# Altium schematic sheet 9.BOOT.SchDoc(9.BOOT)
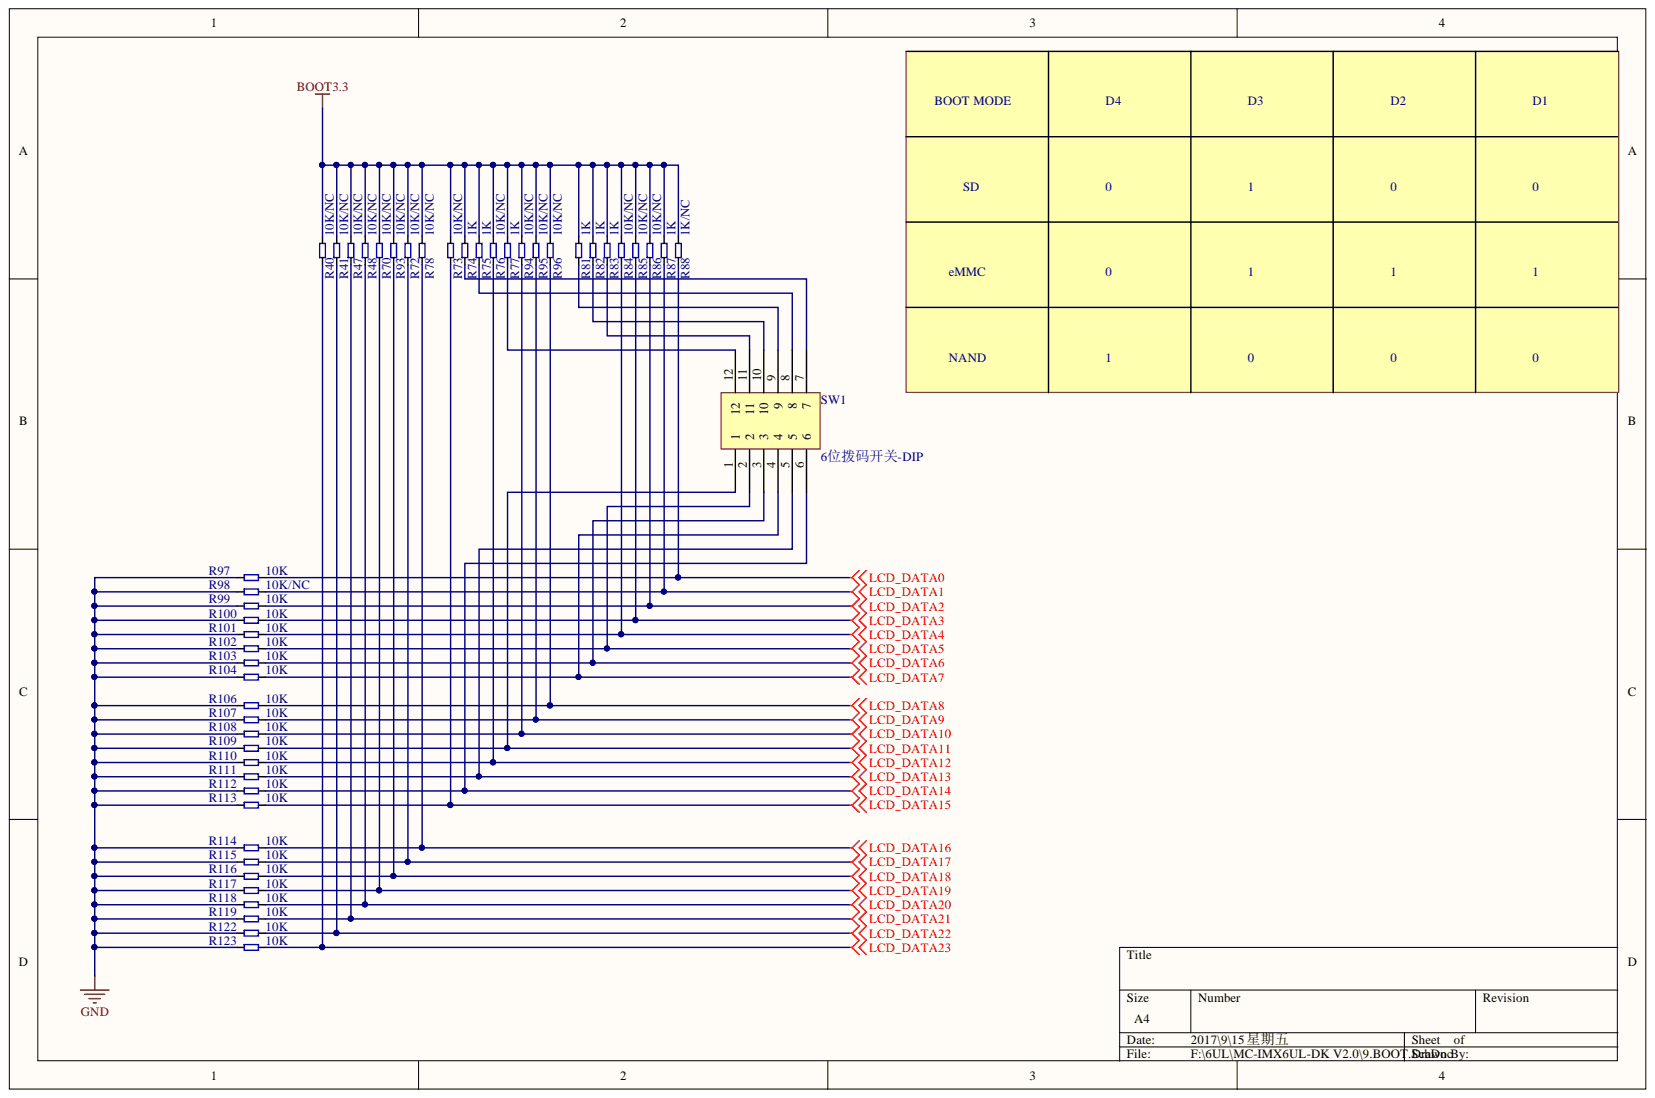
[diagram p0: 9.BOOT.SchDoc]

## Parts
R40  10K/NC  [0603R]
R41  10K/NC  [0603R]
R47  10K/NC  [0603R]
R48  10K/NC  [0603R]
R70  10K/NC  [0603R]
R72  10K/NC  [0603R]
R73  10K/NC  [0603R]
R74  1K  [0603R]
R75  1K  [0603R]
R76  10K/NC  [0603R]
R77  1K  [0603R]
R78  10K/NC  [0603R]
R81  1K  [0603R]
R82  1K  [0603R]
R83  1K  [0603R]
R84  10K/NC  [0603R]
R85  10K/NC  [0603R]
R86  10K/NC  [0603R]
R87  1K  [0603R]
R88  1K/NC  [0603R]
R93  10K/NC  [0603R]
R94  10K/NC  [0603R]
R95  10K/NC  [0603R]
R96  10K/NC  [0603R]
R97  10K  [0603R]
R98  10K/NC  [0603R]
R99  10K  [0603R]
R100  10K  [0603R]
R101  10K  [0603R]
R102  10K  [0603R]
R103  10K  [0603R]
R104  10K  [0603R]
R106  10K  [0603R]
R107  10K  [0603R]
R108  10K  [0603R]
R109  10K  [0603R]
R110  10K  [0603R]
R111  10K  [0603R]
R112  10K  [0603R]
R113  10K  [0603R]
R114  10K  [0603R]
R115  10K  [0603R]
R116  10K  [0603R]
R117  10K  [0603R]
R118  10K  [0603R]
R119  10K  [0603R]
R122  10K  [0603R]
R123  10K  [0603R]
SW1  6位拨码开关-DIP  [SW-6P]

## Nets
BOOT3.3: R40.2 R41.2 R47.2 R48.2 R70.2 R72.2 R73.2 R74.2 R75.2 R76.2 R77.2 R78.2 R81.2 R82.2 R83.2 R84.2 R85.2 R86.2 R87.2 R88.2 R93.2 R94.2 R95.2 R96.2
GND: R97.1 R98.1 R99.1 R100.1 R101.1 R102.1 R103.1 R104.1 R106.1 R107.1 R108.1 R109.1 R110.1 R111.1 R112.1 R113.1 R114.1 R115.1 R116.1 R117.1 R118.1 R119.1 R122.1 R123.1
LCD_DATA0: R88.1 R97.2
LCD_DATA1: R87.1 R98.2
LCD_DATA2: R86.1 R99.2
LCD_DATA3: R85.1 R100.2
LCD_DATA4: R84.1 R101.2
LCD_DATA5: R102.2 SW1.2
LCD_DATA6: R103.2 SW1.3
LCD_DATA7: R104.2 SW1.4
LCD_DATA8: R96.1 R106.2
LCD_DATA9: R95.1 R107.2
LCD_DATA10: R94.1 R108.2
LCD_DATA11: R109.2 SW1.1
LCD_DATA12: R76.1 R110.2
LCD_DATA13: R111.2 SW1.5
LCD_DATA14: R112.2 SW1.6
LCD_DATA15: R73.1 R113.2
LCD_DATA16: R78.1 R114.2
LCD_DATA17: R72.1 R115.2
LCD_DATA18: R93.1 R116.2
LCD_DATA19: R70.1 R117.2
LCD_DATA20: R48.1 R118.2
LCD_DATA21: R47.1 R119.2
LCD_DATA22: R41.1 R122.2
LCD_DATA23: R40.1 R123.2
NetR74_1: R74.1 SW1.7
NetR75_1: R75.1 SW1.8
NetR77_1: R77.1 SW1.12
NetR81_1: R81.1 SW1.9
NetR82_1: R82.1 SW1.10
NetR83_1: R83.1 SW1.11

## Library data of the parts
R40 R41 R47 R48 R70 R72-R78 R81-R88 R93-R104 R106-R119 R122 R123 = 电阻: Component Kind: Standard; Description: 电阻; Library Name: LDT-SCH.SCHLIB
SW1 = 6位拨码开关-DIP: Component Kind: Standard; Library Name: LDT-SCH.SCHLIB
